# Altium schematic sheet MCU.SchDoc(MCU)
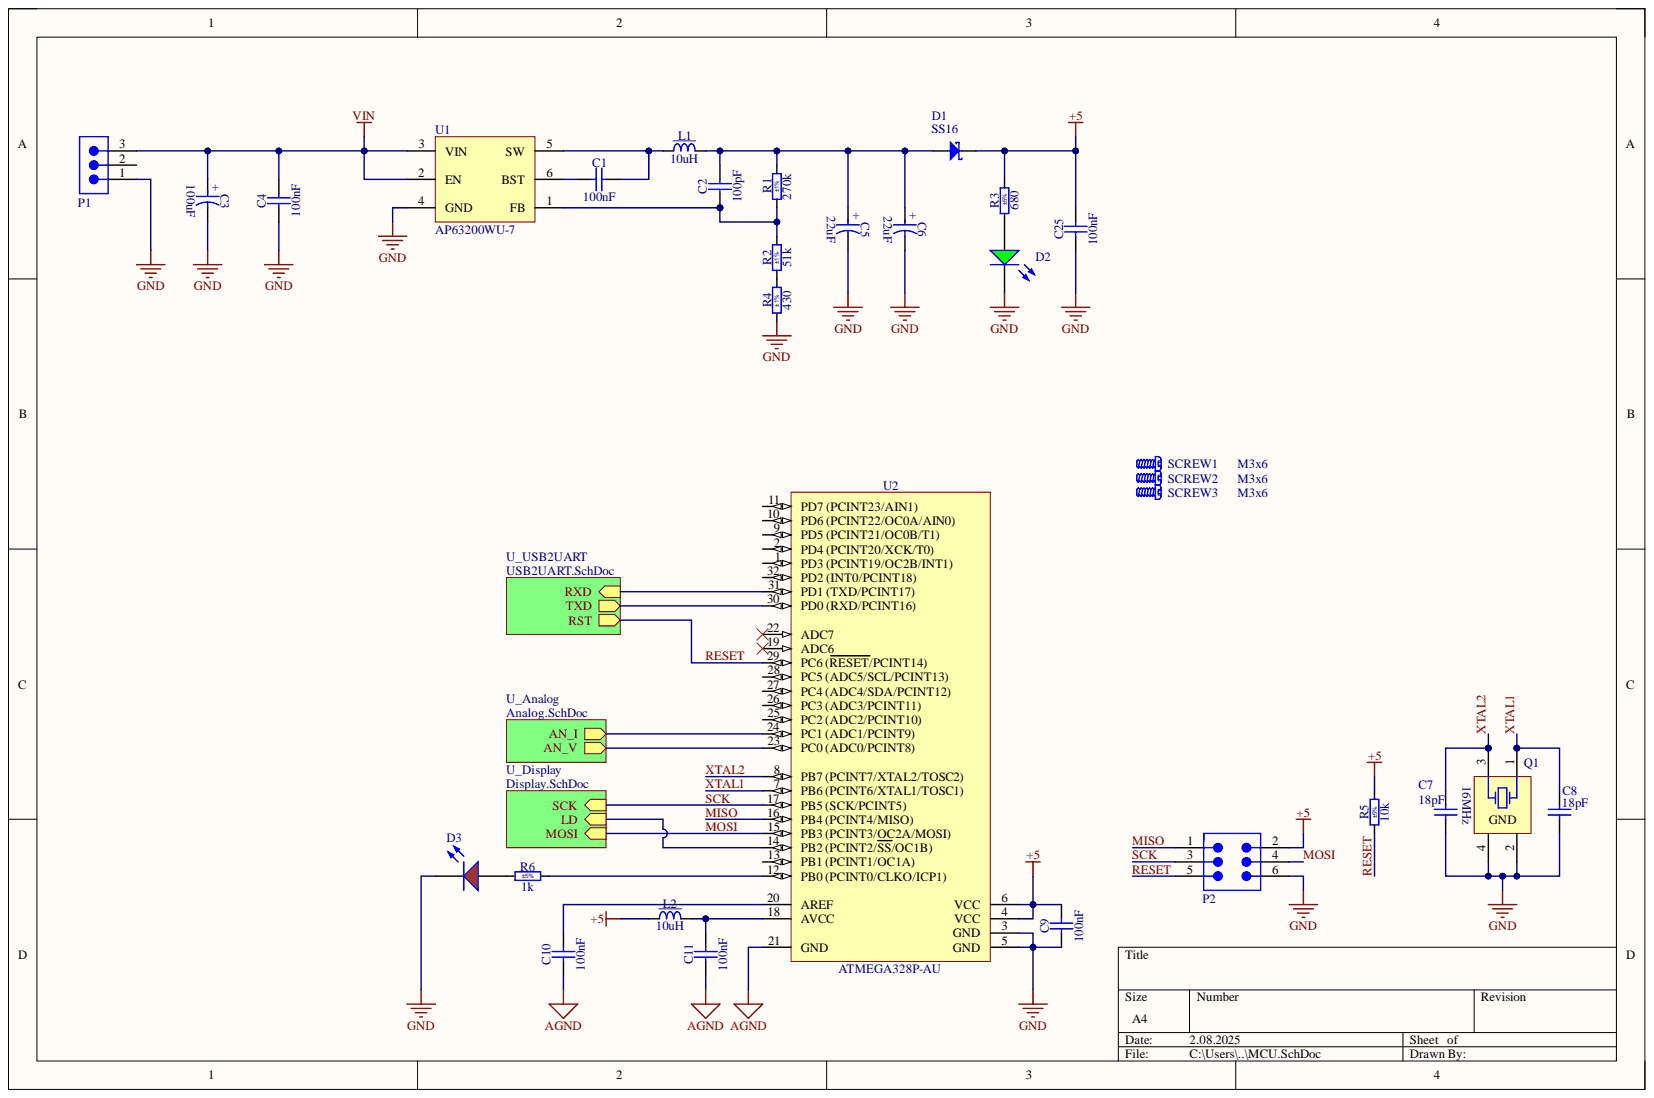
[diagram p0: MCU.SchDoc]

## Parts
C1  100nF  [CAPC2012X135N]
C2  100pF  [CAPC2012X135N]
C3  100uF  [CAPPR250-680X1250]
C4  100nF  [CAPC2012X135N]
C5  22uF  [CAPPR250-500X1100]
C6  22uF  [CAPPR250-500X1100]
C7  18pF  [CAPC2012X135N]
C8  18pF  [CAPC2012X135N]
C9  100nF  [CAPC2012X135N]
C10  100nF  [CAPC2012X135N]
C11  100nF  [CAPC2012X135N]
C25  100nF  [CAPC2012X135N]
D1  SS16  [DIOM5127X229N]
D2  Green, 3.2V, 140°  [WL-SMCW_0805_150080xx75000]  (value not drawn)
D3  Red, 2V, 140°  [WL-SMCW_0805_150080xx75000]  (value not drawn)
L1  10uH  [IND_TRACO_TCK-047]
L2  10uH  [INDC2014X145N]
P1  691322310003  [691322310003]  (value not drawn)
P2  61300621121  [61300621121]  (value not drawn)
Q1  16MHz  [XTAL_EPSON_TSX-3225]
R1  270k  Tolerance: ±1%  [RESC2012X60N]
R2  51k  Tolerance: ±1%  [RESC2012X60N]
R3  680  Tolerance: ±5%  [RESC2012X60N]
R4  430  Tolerance: ±1%  [RESC2012X60N]
R5  10k  Tolerance: ±5%  [RESC2012X60N]
R6  1k  Tolerance: ±5%  [RESC2012X60N]
SCREW1  M3x6  [BOSSARD_BN5687-3061605_v]
SCREW2  M3x6  [BOSSARD_BN5687-3061605_v]
SCREW3  M3x6  [BOSSARD_BN5687-3061605_v]
U1  AP63200WU-7  [TSOT26]
U2  ATMEGA328P-AU  [QFP80P900X900X120-32N]

## Nets
+5: C9.2 C25.2 D1.1 L2.1 P2.2 R3.2 R5.2 U2.4(VCC) U2.6(VCC)
AGND: C10.1 C11.1 U2.21(GND)
GND: C3.2 C4.1 C5.2 C6.2 C7.1 C8.2 C9.1 C25.1 D2.1 D3.1 P1.1 P2.6 Q1.2 Q1.4 R4.1 U1.4(GND) U2.3(GND) U2.5(GND)
VIN: C3.1 C4.2 P1.3 U1.2(EN) U1.3(VIN)
MISO: P2.1 U2.16(PB4_(PCINT4/MISO))
MOSI: P2.4 U2.15(PB3_(PCINT3/OC2A/MOSI))
RESET: P2.5 R5.1 U2.29(PC6_(RESET/PCINT14))
SCK: P2.3 U2.17(PB5_(SCK/PCINT5))
XTAL1: C8.1 Q1.1 U2.7(PB6_(PCINT6/XTAL1/TOSC1))
XTAL2: C7.2 Q1.3 U2.8(PB7_(PCINT7/XTAL2/TOSC2))
NetC1_1: C1.1 U1.6(BST)
NetC1_2: C1.2 L1.1 U1.5(SW)
NetC2_1: C2.1 R1.1 R2.2 U1.1(FB)
NetC2_2: C2.2 C5.1 C6.1 D1.2 L1.2 R1.2
NetC10_2: C10.2 U2.20(AREF)
NetC11_2: C11.2 L2.2 U2.18(AVCC)
NetD2_2: D2.2 R3.1
NetD3_2: D3.2 R6.1
NetP1_2: P1.2
NetR2_1: R2.1 R4.2
NetR6_2: R6.2 U2.12(PB0_(PCINT0/CLKO/ICP1))
NetU2_1: U2.1(PD3_(PCINT19/OC2B/INT1))
NetU2_2: U2.2(PD4_(PCINT20/XCK/T0))
NetU2_9: U2.9(PD5_(PCINT21/OC0B/T1))
NetU2_10: U2.10(PD6_(PCINT22/OC0A/AIN0))
NetU2_11: U2.11(PD7_(PCINT23/AIN1))
NetU2_13: U2.13(PB1_(PCINT1/OC1A))
NetU2_14: U2.14(PB2_(PCINT2/SS/OC1B))
NetU2_19: U2.19(ADC6)
NetU2_22: U2.22(ADC7)
NetU2_23: U2.23(PC0_(ADC0/PCINT8))
NetU2_24: U2.24(PC1_(ADC1/PCINT9))
NetU2_25: U2.25(PC2_(ADC2/PCINT10))
NetU2_26: U2.26(PC3_(ADC3/PCINT11))
NetU2_27: U2.27(PC4_(ADC4/SDA/PCINT12))
NetU2_28: U2.28(PC5_(ADC5/SCL/PCINT13))
NetU2_30: U2.30(PD0_(RXD/PCINT16))
NetU2_31: U2.31(PD1_(TXD/PCINT17))
NetU2_32: U2.32(PD2_(INT0/PCINT18))

## Library data of the parts
C1 C4 C9-C11 C25 = CC0805_100NF_50V_10%_X7R: Non-polarised capacitor; Manufacturer: GENERIC; Manufacturer1 Part Number: C2012X7R1H104K085; Part Description: SMD Multilayer Chip Ceramic Capacitor; Database Table Name: Capacitors SMD; TC: X7R; Tolerance: ±10%; Voltage: 50V; Case: 0805; Component Kind: Standard; Component Type: Standard; CreateDate: 3.12.2007; HelpURL: \\cern.ch\dfs\Applications\Altium\Datasheets\CC0805_X7R_TDK_C.pdf; LatestRevisionDate: 22.04.2015; Library Name: Capacitors.DbLib; Manufacturer1 ComponentHeight: 1mm; Manufacturer1 Example: TDK; Manufacturer Part Number: CC0805_100NF_50V_10%_X7R; Mounted: Yes; Part Number: CC0805_100NF_50V_10%_X7R; Pin Count: 2; Sense: No; SMD: Yes; Socket: No; Status: None; Value: 100nF; Author: CERN DEM JLC
C2 = CC0805_100PF_50V_5%_NP0: Non-polarised capacitor; Manufacturer: GENERIC; Manufacturer1 Part Number: 223886115101; Part Description: SMD Multilayer Chip Ceramic Capacitor; Database Table Name: Capacitors SMD; TC: C0G/NP0; Tolerance: ±5%; Voltage: 50V; Case: 0805; Component Kind: Standard; Component Type: Standard; CreateDate: 3.12.2007; HelpURL: \\cern.ch\dfs\Applications\Altium\Datasheets\CC0805_NP0_PHYCOMP.pdf; LatestRevisionDate: 3.12.2007; Library Name: Capacitors.DbLib; Manufacturer1 ComponentHeight: 1.35mm; Manufacturer1 Example: PHYCOMP; Manufacturer Part Number: CC0805_100PF_50V_5%_NP0; Mounted: Yes; Part Number: CC0805_100PF_50V_5%_NP0; Pin Count: 2; Sense: No; SMD: Yes; Socket: No; Status: Preferred; Value: 100pF; Author: CERN DEM JLC
C3 = CAER_100UF_25V_20%_NICHICON_UPS1E101MED: Polarised capacitor; Part Description: Aluminium Electrolytic Capacitor (UPS Series); Database Table Name: Capacitors THD; Tolerance: ±20%; Voltage: 25V; Case: LS2.5 D6.3 x H11; Component Kind: Standard; Component Type: Standard; ComponentHeight: 12.5mm; CreateDate: 30.05.2016; HelpURL: \\cern.ch\dfs\Applications\Altium\Datasheets\CAER_NICHICON_UPS.pdf; LatestRevisionDate: 30.05.2016; Library Name: Capacitors.DbLib; Manufacturer: NICHICON; Manufacturer Part Number: UPS1E101MED; Mounted: Yes; Part Number: CAER_100UF_25V_20%_NICHICON_UPS1E101MED; Pin Count: 2; Sense: No; SMD: No; Socket: No; Status: None; Value: 100uF; Author: CERN DEM MR
C5 C6 = CAER_47UF_25V_20%_250-500X1100: Polarised capacitor; Manufacturer: GENERIC; Manufacturer1 Part Number: EEUFC1E470; Part Description: Aluminum Electrolytic Capacitor; Part Number: CAER_22UF_25V_20%_250-500X1100; Database Table Name: Capacitors THD; Tolerance: ±20%; Voltage: 25V; Case: LS2.5 D5 x H11; Component Kind: Standard; Component Type: Standard; ComponentHeight: 11mm; CreateDate: 18.12.2007; HelpURL: \\cern.ch\dfs\Applications\Altium\Datasheets\CAER_PANASONIC_FC.pdf; LatestRevisionDate: 18.12.2007; Library Name: Capacitors.DbLib; Manufacturer1 ComponentHeight: 11mm; Manufacturer1 Example: PANASONIC; Manufacturer Part Number: CAER_47UF_25V_20%_250-500X1100; Mounted: Yes; Pin Count: 2; Sense: No; SMD: No; Socket: No; Status: Preferred; Value: 22uF; Author: CERN DEM JLC
C7 C8 = CC0805_18PF_50V_5%_NP0: Non-polarised capacitor; Manufacturer: GENERIC; Manufacturer1 Part Number: 223886115189; Part Description: SMD Multilayer Chip Ceramic Capacitor; Database Table Name: Capacitors SMD; TC: C0G/NP0; Tolerance: ±5%; Voltage: 50V; Case: 0805; Component Kind: Standard; Component Type: Standard; CreateDate: 3.12.2007; HelpURL: \\cern.ch\dfs\Applications\Altium\Datasheets\CC0805_NP0_PHYCOMP.pdf; LatestRevisionDate: 3.12.2007; Library Name: Capacitors.DbLib; Manufacturer1 ComponentHeight: 1.35mm; Manufacturer1 Example: PHYCOMP; Manufacturer Part Number: CC0805_18PF_50V_5%_NP0; Mounted: Yes; Part Number: CC0805_18PF_50V_5%_NP0; Pin Count: 2; Sense: No; SMD: Yes; Socket: No; Status: Preferred; Value: 18pF; Author: CERN DEM JLC
D1 = SS16: Schottky Diode; Manufacturer: VISHAY GENERAL SEMICONDUCTOR; Part Description: Surface Mount Schottky Barrier Rectifier; Bonding: No; Database Table Name: Diodes; Power: 1A; PressFit: No; Voltage: 60V; Case: DO-214AC; Component Kind: Standard; Component Type: Standard; ComponentHeight: 2.29mm; CreateDate: 15.07.2008; Device: SS16; HelpURL: \\cern.ch\dfs\Applications\Altium\Datasheets\SS16.pdf; LatestRevisionDate: 23.08.2010; Library Name: ICs And Semiconductors.DbLib; Manufacturer Part Number: SS16; Mounted: Yes; PackageDescription: DO-214-AC/SMA Diode, Molded Body; 5.10mm L X 2.67mm W X 2.29mm H, IPC Medium Density; Part Number: SS16; Pin Count: 2; Sense: No; SMD: Yes; Socket: No; Author: CERN DEM JLC
D2 = 150080GS75000: WL-SMCW Mono-color Chip LED Waterclear, size 0805, Green, 3.2V, 140°; Manufacturer: Wurth Elektronik; Case/Size Code: 0805; Chip Technology: InGaN; Database Table Name: LEDs; Dominant Wavelength: 525nm; Luminous Intensity: 450mcd; Peak Wavelength: 515nm; Category: Signal LEDs; Component Kind: Standard; ComponentLink1Description: Website Link; ComponentLink1URL: https://www.we-online.com/redexpert/article/150080GS75000?ad; ComponentLink2Description: Datasheet Link; ComponentLink2URL: https://www.we-online.com/redexpert/spec/150080GS75000?ad; Emitting Color: Green; Forward Voltage: 3.2V; Height: 0.7mm; Length: 2mm; Library Name: WE - Optoelectronic Components.DbLib; Manufacturer Part Number: 150080GS75000; Match Code: WL-SMCW; Mount: Surface Mount; Operating Temperature Max: 85°C; Operating Temperature Min: -40°C; Packaging: Tape and Reel; Simulation: 0805_150080GS75000; Viewing Angle: 140°; Width: 1.25mm
D3 = 150080RS75000: WL-SMCW Mono-color Chip LED Waterclear, size 0805, Red, 2V, 140°; Manufacturer: Wurth Elektronik; Case/Size Code: 0805; Chip Technology: AlInGaP; Database Table Name: LEDs; Dominant Wavelength: 625nm; Luminous Intensity: 150mcd; Peak Wavelength: 630nm; Category: Signal LEDs; Component Kind: Standard; ComponentLink1Description: Website Link; ComponentLink1URL: https://www.we-online.com/redexpert/article/150080RS75000?ad; ComponentLink2Description: Datasheet Link; ComponentLink2URL: https://www.we-online.com/redexpert/spec/150080RS75000?ad; Emitting Color: Red; Forward Voltage: 2V; Height: 0.7mm; Length: 2mm; Library Name: WE - Optoelectronic Components.DbLib; Manufacturer Part Number: 150080RS75000; Match Code: WL-SMCW; Mount: Surface Mount; Operating Temperature Max: 85°C; Operating Temperature Min: -40°C; Packaging: Tape and Reel; Simulation: 0805_150080RS75000; Viewing Angle: 140°; Width: 1.25mm
L1 = IND_10UH_20%_TRACO_TCK-047: Inductor With Core; Manufacturer: TRACO POWER; Part Description: SMD Common Mode Choke; Database Table Name: Inductors SMD; Power: 1.44A; Resistance: 0.1R; Tolerance: ±20%; Component Kind: Standard; Component Type: Standard; ComponentHeight: 4.85mm; CreateDate: 16.11.2017; HelpURL: \\cern.ch\dfs\Applications\Altium\Datasheets\IND_TRACO_TCK-047.pdf; LatestRevisionDate: 16.11.2017; Library Name: Inductors & Transformers.DbLib; Manufacturer Part Number: TCK-047; Mounted: Yes; Part Number: IND_10UH_20%_TRACO_TCK-047; Pin Count: 2; Sense: No; SMD: Yes; Socket: No; Value: 10uH; Author: CERN DEM JLC
L2 = IND0805_10NH_5%_EPCOS_B82498B: Inductor With Core; Manufacturer: EPCOS; Manufacturer Part Number: B82498B3100J; Part Description: SMT Inductor SIMID Series; Part Number: IND0805_10UH_5%_EPCOS_B82498B; Database Table Name: Inductors SMD; Power: 700mA; Resistance: 0.03R; Tolerance: ±5%; Case: 0805; Component Kind: Standard; Component Type: Standard; ComponentHeight: 1.45mm; CreateDate: 11.02.2008; HelpURL: \\cern.ch\dfs\Applications\Altium\Datasheets\IND0805_EPCOS_B82498B.pdf; LatestRevisionDate: 26.06.2008; Library Name: Inductors & Transformers.DbLib; Mounted: Yes; Pin Count: 2; Sense: No; SMD: Yes; Socket: No; Status: Preferred; Value: 10uH; Author: CERN DEM JLC
P1 = 691322310003: WR-TBL Series 322 Horizontal PCB Header, THT, pitch 3.81mm, 3p; Manufacturer: Wurth Elektronik; Database Table Name: Connectors; Modularity: No; Rated Current: 10A; Working Voltage: 300V; Application: Pluggable; Category: Terminal Blocks; Component Kind: Standard; ComponentLink1Description: Website Link; ComponentLink1URL: https://www.we-online.com/redexpert/article/691322310003?ad; ComponentLink2Description: Datasheet Link; ComponentLink2URL: https://www.we-online.com/redexpert/spec/691322310003?ad; Library Name: WE - Electromechanical Components.DbLib; Manufacturer Part Number: 691322310003; Match Code: WR-TBL; Mount: Through Hole; Number of Pins: 3; Number of Rows: 1; Operating Temperature Max: 105°C; Operating Temperature Min: -40°C; Orientation: Horizontal; Packaging: Box; Pitch: 3.81mm
P2 = 61300621121: WR-PHD Pin Header, THT, Vertical, pitch 2.54mm, 2 Row, 6P; Manufacturer: Wurth Elektronik; Contact Resistance: 20mOhm; Database Table Name: Connectors; Rated Current: 3A; Withstanding Voltage: 500V; Working Voltage: 250V; Category: Board-to-Board Connectors; Component Kind: Standard; ComponentLink1Description: Website Link; ComponentLink1URL: https://www.we-online.com/redexpert/article/61300621121?ad; ComponentLink2Description: Datasheet Link; ComponentLink2URL: https://www.we-online.com/redexpert/spec/61300621121?ad; Gender: Pin Header; Library Name: WE - Electromechanical Components.DbLib; Manufacturer Part Number: 61300621121; Match Code: WR-PHD; Mount: Through Hole; Number of Pins: 6; Number of Rows: 2; Operating Temperature Max: 105°C; Operating Temperature Min: -40°C; Orientation: Vertical; Packaging: Bag; Pitch: 2.54mm
Q1 = XTAL_40MHZ_EPSON_TSX-3225 40.0000MF10Z-B6: Crystal 4 pins 1=XTAL Control 2=GND3=XTAL 4=GND; Manufacturer: EPSON; Part Description: Tolerance: ±10ppm,  Stability:±10ppm, -20°C to 75°C, 10pF, Surface Mount Quartz Crystal, TX-3225 Series; Part Number: XTAL_16MHZ_EPSON_TSX-3225 40.0000MF10Z-B6; Bonding: No; Database Table Name: Crystals & Oscillators; PressFit: No; Component Kind: Standard; Component Type: Standard; ComponentHeight: 0.6mm; ComponentLink1Description: Ordering Informations; ComponentLink1URL: \\cern.ch\dfs\Applications\Altium\Datasheets\XTAL_EPSON_TSX-3225_Ordering-Informations.pdf; CreateDate: 24.10.2023; HelpURL: \\cern.ch\dfs\Applications\Altium\Datasheets\XTAL_EPSON_TSX-3225.pdf; LatestRevisionDate: 24.10.2023; Library Name: ICs And Semiconductors.DbLib; Manufacturer Part Number: TSX-3225 40.0000MF10Z-B6; Mounted: Yes; PackageDescription: Crystal, Corner Concave; 4 Pin, 2.50mm L X 3.20mm W X 0.6mm H, TSX-3225 Serie; Pin Count: 4; Sense: No; SMD: Yes; Socket: No; Value: 16MHz; Author: CERN DEM HF
R1 = R0805_270K_1%_0.125W_100PPM: Resistor - 1%; Manufacturer: GENERIC; Manufacturer1 Part Number: NRC10F2703TRF; Part Description: General Purpose Thick Film Chip Resistor; Database Table Name: Resistors SMD; Power: 0.125W; PressFit: No; TC: ±100ppm/°C; Case: 0805; Component Kind: Standard; Component Type: Standard; CreateDate: 3.12.2007; HelpURL: \\cern.ch\dfs\Applications\Altium\Datasheets\R0805_NIC_NRC.pdf; LatestRevisionDate: 13.03.2008; Library Name: Resistors.DbLib; Manufacturer1 ComponentHeight: 0.6mm; Manufacturer1 Example: NIC COMPONENT; Manufacturer Part Number: R0805_270K_1%_0.125W_100PPM; Mounted: Yes; Part Number: R0805_270K_1%_0.125W_100PPM; Pin Count: 2; Sense: No; SMD: Yes; Socket: No; Status: Preferred; Value: 270k; Author: CERN DEM JLC
R2 = R0805_51K_1%_0.125W_100PPM: Resistor - 1%; Manufacturer: GENERIC; Manufacturer1 Part Number: NRC10F5102TRF; Part Description: General Purpose Thick Film Chip Resistor; Database Table Name: Resistors SMD; Power: 0.125W; PressFit: No; TC: ±100ppm/°C; Case: 0805; Component Kind: Standard; Component Type: Standard; CreateDate: 3.12.2007; HelpURL: \\cern.ch\dfs\Applications\Altium\Datasheets\R0805_NIC_NRC.pdf; LatestRevisionDate: 13.03.2008; Library Name: Resistors.DbLib; Manufacturer1 ComponentHeight: 0.6mm; Manufacturer1 Example: NIC COMPONENT; Manufacturer Part Number: R0805_51K_1%_0.125W_100PPM; Mounted: Yes; Part Number: R0805_51K_1%_0.125W_100PPM; Pin Count: 2; Sense: No; SMD: Yes; Socket: No; Status: Preferred; Value: 51k; Author: CERN DEM JLC
R3 = R0805_680R_1%_0.125W_100PPM: Resistor - 5%; Manufacturer: GENERIC; Manufacturer1 Part Number: NRC10F6800TRF; Manufacturer Part Number: R0805_680R_5%_0.125W_100PPM; Part Description: General Purpose Thick Film Chip Resistor; Database Table Name: Resistors SMD; Power: 0.125W; PressFit: No; TC: ±100ppm/°C; Case: 0805; Component Kind: Standard; Component Type: Standard; CreateDate: 3.12.2007; HelpURL: \\cern.ch\dfs\Applications\Altium\Datasheets\R0805_NIC_NRC.pdf; LatestRevisionDate: 13.03.2008; Library Name: Resistors.DbLib; Manufacturer1 ComponentHeight: 0.6mm; Manufacturer1 Example: NIC COMPONENT; Mounted: Yes; Part Number: R0805_680R_5%_0.125W_100PPM; Pin Count: 2; Sense: No; SMD: Yes; Socket: No; Status: Preferred; Value: 680; Author: CERN DEM JLC
R4 = R0805_430R_1%_0.125W_100PPM: Resistor - 1%; Manufacturer: GENERIC; Manufacturer1 Part Number: NRC10F4300TRF; Part Description: General Purpose Thick Film Chip Resistor; Database Table Name: Resistors SMD; Power: 0.125W; PressFit: No; TC: ±100ppm/°C; Case: 0805; Component Kind: Standard; Component Type: Standard; CreateDate: 3.12.2007; HelpURL: \\cern.ch\dfs\Applications\Altium\Datasheets\R0805_NIC_NRC.pdf; LatestRevisionDate: 13.03.2008; Library Name: Resistors.DbLib; Manufacturer1 ComponentHeight: 0.6mm; Manufacturer1 Example: NIC COMPONENT; Manufacturer Part Number: R0805_430R_1%_0.125W_100PPM; Mounted: Yes; Part Number: R0805_430R_1%_0.125W_100PPM; Pin Count: 2; Sense: No; SMD: Yes; Socket: No; Status: Preferred; Value: 430; Author: CERN DEM JLC
R5 = R0805_10K_1%_0.125W_100PPM: Resistor - 5%; Manufacturer: GENERIC; Manufacturer1 Part Number: NRC10F1002TRF; Manufacturer Part Number: R0805_10K_5%_0.125W_100PPM; Part Description: General Purpose Thick Film Chip Resistor; Database Table Name: Resistors SMD; Power: 0.125W; PressFit: No; TC: ±100ppm/°C; Case: 0805; Component Kind: Standard; Component Type: Standard; CreateDate: 3.12.2007; HelpURL: \\cern.ch\dfs\Applications\Altium\Datasheets\R0805_NIC_NRC.pdf; LatestRevisionDate: 13.03.2008; Library Name: Resistors.DbLib; Manufacturer1 ComponentHeight: 0.6mm; Manufacturer1 Example: NIC COMPONENT; Mounted: Yes; Part Number: R0805_10K_5%_0.125W_100PPM; Pin Count: 2; Sense: No; SMD: Yes; Socket: No; Status: Preferred; Value: 10k; Author: CERN DEM JLC
R6 = R0805_1K_1%_0.125W_100PPM: Resistor - 5%; Manufacturer: GENERIC; Manufacturer1 Part Number: NRC10F1001TRF; Manufacturer Part Number: R0805_1K_5%_0.125W_100PPM; Part Description: General Purpose Thick Film Chip Resistor; Database Table Name: Resistors SMD; Power: 0.125W; PressFit: No; TC: ±100ppm/°C; Case: 0805; Component Kind: Standard; Component Type: Standard; CreateDate: 3.12.2007; HelpURL: \\cern.ch\dfs\Applications\Altium\Datasheets\R0805_NIC_NRC.pdf; LatestRevisionDate: 13.03.2008; Library Name: Resistors.DbLib; Manufacturer1 ComponentHeight: 0.6mm; Manufacturer1 Example: NIC COMPONENT; Mounted: Yes; Part Number: R0805_1K_5%_0.125W_100PPM; Pin Count: 2; Sense: No; SMD: Yes; Socket: No; Status: Preferred; Value: 1k; Author: CERN DEM JLC
SCREW1-SCREW3 = BOSSARD_BN5687-3061605_v: Screw; Manufacturer Part Number: BN5687 Art.3061605; Part Description: M3 L=6mm Hexalobular Socket Pan Head Screw, Fully Threaded, Stainless Steel A2; Database Table Name: Fasteners & Fixings; PressFit: No; Component Kind: Standard; Component Type: Mechanical; ComponentHeight: 2.4mm; CreateDate: 15.01.2013; HelpURL: \\cern.ch\dfs\Applications\Altium\Datasheets\BOSSARD_BN5687-3061605.pdf; LatestRevisionDate: 15.01.2013; Library Name: Eletro-mechanical.DbLib; Manufacturer: BOSSARD; Mounted: Yes; PackageDescription: M3 L=6mm Hexalobular Socket Pan Head Screw, Fully Threaded - Stainless Steel A2; Part Number: BOSSARD_BN5687-3061605_v; Pin Count: 0; Sense: No; SMD: No; Socket: No; Status: None; Value: M3x6; Author: CERN DEM JLC
U1 = AP63200WU-7: Switching Regulator; Manufacturer: Diodes; Category: Voltage Regulators - Switching; Component Kind: Standard; ComponentLink1Description: https://datasheet.ciiva.com:443/pdfs/VipMasterIC/IC/DIOD/DIOD-S-A0007089856/DIOD-S-A0007089856-1.pdf?src-supplier=IHS+Markit; ComponentLink1URL: https://datasheet.ciiva.com:443/pdfs/VipMasterIC/IC/DIOD/DIOD-S-A0007089856/DIOD-S-A0007089856-1.pdf?src-supplier=IHS+Markit; ComponentLink2Description: https://www.diodes.com/assets/Datasheets/AP63200-AP63201-AP63203-AP63205.pdf?src-supplier=Digikey; ComponentLink2URL: https://www.diodes.com/assets/Datasheets/AP63200-AP63201-AP63203-AP63205.pdf?src-supplier=Digikey; Manufacturer Part Number: AP63200WU-7; Pricing: 3000=0,285, 6000=0,28, 9000=0,275, 12000=0,275, 15000=0,27 (USD); RoHS: Yes; Stock: 9000; Supplier: Future Electronics; Supplier Part Number: 2108618; Library Name: IKU-LIB.IntLib
U2 = ATMEGA328P-AU: 8-bit AVR Microcontroller, 32KB Flash, 1KB EEPROM, 2KB SRAM, 32-Pin TQFP; Manufacturer: ATMEL; Part Description: 8-bit AVR Microcontroller, 32KB Flash, 1KB EEPROM, 2KB SRAM, 32-Pin TQFP, Industrial Grade (-40°C to 85°C); Bonding: No; Database Table Name: Logic; PressFit: No; Case: QFP32; Component Kind: Standard; Component Type: Standard; ComponentHeight: 1.2mm; CreateDate: 2.07.2014; Device: ATMEGA328P-AU; HelpURL: \\cern.ch\dfs\Applications\Altium\Datasheets\ATMEGA328P-AU.pdf; LatestRevisionDate: 2.07.2014; Library Name: ICs And Semiconductors.DbLib; Manufacturer Part Number: ATMEGA328P-AU; Mounted: Yes; PackageDescription: QFP, 0.80mm Pitch, Square; 32 Pin, 7.00mm L X 7.00mm W X 1.20mm H body, IPC Medium Density; Part Number: ATMEGA328P-AU; Pin Count: 32; Sense: No; SMD: Yes; Socket: No; Author: CERN DEM JLC
